# Altium schematic sheet Sheet1.SchDoc(Sheet1)
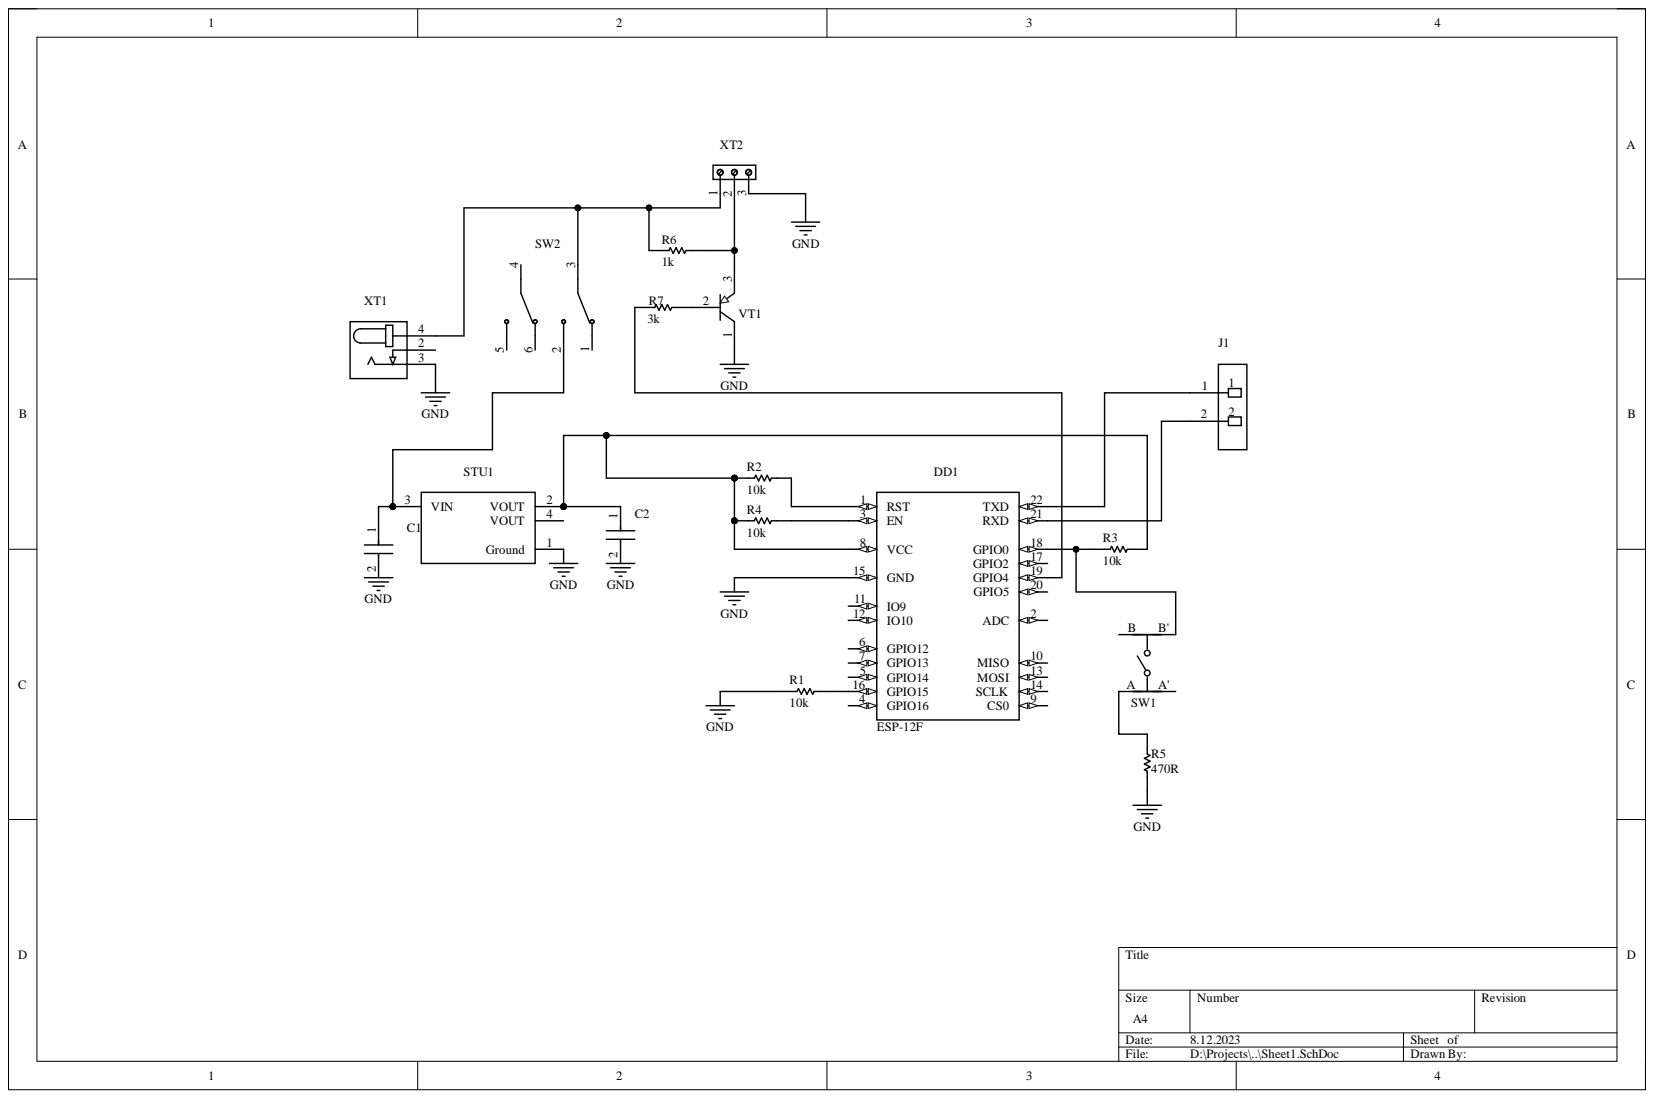
[diagram p0: Sheet1.SchDoc]

## Parts
C1  GRM188R60J106ME47D  [FP-GRM188-0_1-e0_2_0_55-IPC_B]  (value not drawn)
C2  GRM188R60J106ME47D  [FP-GRM188-0_1-e0_2_0_55-IPC_B]  (value not drawn)
DD1  ESP-12F  [ESP-12F]
J1  PEC02SABN  [FP-PEC02SABN-MFG]  (value not drawn)
R1  10k  [RESC1609X50X30ML8T20]
R2  10k  [RESC1609X50X30ML8T20]
R3  10k  [RESC1609X50X30ML8T20]
R4  10k  [RESC1609X50X30ML8T20]
R5  470R  [RESC1609X50X30ML8T20]
R6  1k  [RESC1609X50X30ML8T20]
R7  3k  [RESC1609X50X30ML8T20]
STU1  AMS1117-3.3  [FP-AMS1117-IPC_C]  (value not drawn)
SW1  EVQ-PAE09K  [FP-EVQ-PAE09K-MFG]  (value not drawn)
SW2  TL2285OA  [SW_TL2285OA]  (value not drawn)
VT1  BC327-40ZL1G  [ONSC-TO-92-3-29-11_AM_BL]  (value not drawn)
XT1  DC-005  [NINIGI_DC-005]  (value not drawn)
XT2  691102710003  [691102710003]  (value not drawn)

## Nets
GND: C1.2 C2.2 DD1.15(GND) R1.1 R5.1 STU1.1(Ground) VT1.1 XT1.3 XT2.3
NetC1_1: C1.1 STU1.3(VIN) SW2.2
NetC2_1: C2.1 DD1.8(VCC) R2.1 R3.2 R4.1 STU1.2(VOUT)
NetDD1_1: DD1.1(RST) R2.2
NetDD1_3: DD1.3(EN) R4.2
NetDD1_16: DD1.16(GPIO15) R1.2
NetDD1_18: DD1.18(GPIO0) R3.1 SW1.B'
NetDD1_19: DD1.19(GPIO4) R7.1
NetDD1_21: DD1.21(RXD) J1.2
NetDD1_22: DD1.22(TXD) J1.1
NetR5_2: R5.2 SW1.A
NetR6_1: R6.1 SW2.3 XT1.4 XT2.1
NetR6_2: R6.2 VT1.3 XT2.2
NetR7_2: R7.2 VT1.2

Not connected: DD1 2(ADC) 4(GPIO16) 5(GPIO14) 6(GPIO12) 7(GPIO13) 9(CS0) 10(MISO) 11(IO9) 12(IO10) 13(MOSI) 14(SCLK) 17(GPIO2) 20(GPIO5); STU1 4(VOUT); SW1 A' B; SW2 1 4 5 6; XT1 2

## Library data of the parts
C1 C2 = CMP-2006-02792-2: Chip Multilayer Ceramic Capacitors for General Purpose, 0603, 10uF, X5R, 15%, 20%, 6.3V; Capacitance: 10uF; Case Code (Imperial): 0603; Case Code (Metric): 1608; Case/Package: 0603; Component Kind: Standard; Depth: 0.8mm; Dielectric: X5R; Height: 0.8mm; Lead Free: Lead Free; Length: 1.6mm; Library Name: Altium Content Vault; Material: Ceramic; Max Operating Temperature: 85°C; Min Operating Temperature: -55°C; Mount: Surface Mount; Package Quantity: 4000; Packaging: Tape and Reel; Radiation Hardening: No; REACH SVHC: No SVHC; Termination: SMD/SMT; Thickness: 0.035inch; Tolerance: 20%; Voltage: 6.3V; Voltage Rating: 6.3V; Voltage Rating (DC): 6.3V; Width: 0.031inch
DD1 = ESP-12F: WiFi Module; MF: AI-Thinker; Availability: No availability data; Check_prices: https://www.snapeda.com/parts/ESP-12F/AI-Thinker/view-part/6648243/?ref=eda; Component Kind: Standard; Library Name: ESP-12F.SchLib; MP: ESP-12F; Package: Package; Price: None; SnapEDA_Link: https://www.snapeda.com/parts/ESP-12F/AI-Thinker/view-part/6648243/?ref=snap
J1 = CMP-25680-000076-1: CONN HEADER VERT 2POS 2.54MM; Connector Type: Male Pin; Gender: Male; Number of Contacts: 2; Pitch: 0.1inch; Color: Black; Component Kind: Standard; Contact Finish - Mating: TIN; Contact Material: Bronze; Contact Mating Length: 0.23inch; Contact Plating: Tin; Contact Shape: Square; Contact Type: Male Pin; Fastening Type: Pull; Flammability Rating: UL94 V-0; Height: 2.54mm; Housing Color: Black; Housing Material: Plastic; Insulation Color: Black; Insulation Material: PBT; Lead Free: Lead Free; Length: 5.08mm; Library Name: Altium Content Vault; Max Operating Temperature: 125°C; Min Operating Temperature: -65°C; Mount: Through Hole; Number of Positions: 2; Number of Rows: 1; Package Quantity: 1; Packaging: Bulk; RoHS Compliant: Yes; Series: -; Termination: Solder; Width: 2.54mm
R1-R7 = CMP-2000-03816-5: Chip Resistor, 0 Ohm, Jumper, 100 mW, -55 to 155 degC, 0603 (1608 Metric), RoHS, Tape and Reel; Manufacturer: Vishay Semiconductor; Voltage Rating: 75 V; Component Kind: Standard; ComponentLink1Description: Manufacturer URL; ComponentLink1URL: http://www.vishay.com/; ComponentLink2Description: Datasheet; ComponentLink2URL: http://www.vishay.com/docs/20035/dcrcwe3.pdf; DatasheetVersion: 06/2012; Height: 0.45 mm; Length: 1.55 mm; Library Name: Altium Content Vault; Max Operating Temperature: 155 degC; Min Operating Temperature: -55 degC; Mount: Surface Mount; Mounting Technology: Surface Mount; Package Quantity: 5000; PackageDescription: 2-Pin Surface Mount Device, Body 1.575 x 0.85 mm; PackageReference: 0603; Packaging: Tape and Reel; Pins: 2; REACH SVHC: Unknown; RoHSCompliant: true
STU1 = CMP-209535-000001-1: LDO Voltage Regulators 1A, 3.3V; Manufacturer: Advanced Monolithic Systems; Dropout Voltage: 1.1V; Max Junction Temp: +125°C; Max Power Dissipation: 1.2W; Max Supply Voltage: 15V; Nominal Supply Current: 5mA; Number Of Outputs: 1; Output Type: Fixed; Standoff Height: 0.64mm; Automotive: No; Category: IC; Component Kind: Standard; ComponentLink2Description: Datasheet; ComponentLink2URL: http://www.advanced-monolithic.com/pdf/ds1117.pdf; Device Class L1: Integrated Circuits (ICs); Device Class L2: Power Management ICs; Device Class L3: Voltage Regulators - Linear; Height: 1.8mm; Ipc Land Pattern Name: SOT229P700X180-4; Lead Free: Yes; Library Name: Altium Content Vault; Manufacturer Part Number: AMS1117-3.3; Octopart: https://octopart.com/ams1117-3.3-advanced+monolithic+systems-25899300; Output Current: 1A; Output Voltage: 3.3V; Package: AMS1117; RoHS: TRUE; Temperature: -40°C to +125°C; Temperature Range High: +125°C; Temperature Range Low: -40°C
SW1 = CMP-05618-000085-1: SWITCH TACTILE SPST-NO 0.02A 15V; Actuator Color: Black; Actuator Length: 5.6mm; Actuator Type: Standard; Contact Current Rating: 20mA; Contact Resistance: 50mR; Electrical Life: 100000Cycles; Illumination Type: Non-Illuminated; Operating Force: 1.6N; Switch Function: Off-Mom; Switch Travel: 0.25mm; Throw Configuration: SPST; Color: Black; Component Kind: Standard; Contact Plating: Gold; Current Rating: 20mA; Depth: 7.8mm; Height: 9.5mm; Lead Free: Lead Free; Length: 6mm; Library Name: Altium Content Vault; Max Current Rating: 20mA; Max Operating Temperature: 70°C; Max Voltage Rating (DC): 15V; Mechanical Life: 100000Cycles; Min Operating Temperature: -20°C; Mount: Through Hole; Orientation: Straight; Package Quantity: 500; Packaging: Bulk; Radiation Hardening: No; RoHS Compliant: Yes; Series: EVQPA; Size: 6mm; Termination: PC Pin; Voltage Rating (DC): 15V; Width: 6mm
SW2 = TL2285OA: MF: {'manufacturer': u'E-Switch'}; Availability: Unavailable; Component Kind: Standard; MP: TL2285OA; Package: None; Price: None
VT1 = CMP-1048-01589-1: Amplifier Transistor, PNP Silicon, 3-Pin TO-92, Pb-Free, Ammo Box; Manufacturer: On Semiconductor; IC Continuous (A): -0.8; Component Kind: Standard; ComponentLink1Description: Manufacturer URL; ComponentLink1URL: http://www.onsemi.com/; ComponentLink2Description: Datasheet; ComponentLink2URL: http://www.onsemi.com/pub_link/Collateral/BC327-D.PDF; ComponentLink3Description: Package Specification; ComponentLink3URL: http://www.onsemi.com/pub_link/Collateral/MAC97-D.PDF; DatasheetVersion: Rev. 6; Library Name: Altium Content Vault; Mounting Technology: Through Hole; PackageDescription: 3-Pin Transistor Outline, Body 4.825 x 3.685 mm, Pitch 1.27 mm; PackageReference: TO-92-3-29-11_AM_BL; PackageVersion: Rev. AM; Packing: Ammo Box; PartNumber: BC327-40ZL1G; Polarity: PNP; RoHS: TRUE
XT1 = DC-005: DC Jack; MF: {'manufacturer': u'Best Inc.'}; Availability: Unavailable; Component Kind: Standard; MP: DC-005; Package: None; Price: None
XT2 = 691102710003: 3 Position Wire to Board Terminal Block Horizontal with Board 0.197 (5.00mm) Through Hole; MF: Wurth Elektronik; Availability: In Stock; Check_prices: https://www.snapeda.com/parts/691102710003/Wurth+Elektronik/view-part/?ref=eda; Component Kind: Standard; Library Name: 691102710003.IntLib; MP: 691102710003; Package: None; Price: None; SnapEDA_Link: https://www.snapeda.com/parts/691102710003/Wurth+Elektronik/view-part/?ref=snap
